# Altium schematic sheet Dosing_Main_v3.SchDoc(Dosing_Main_v3)
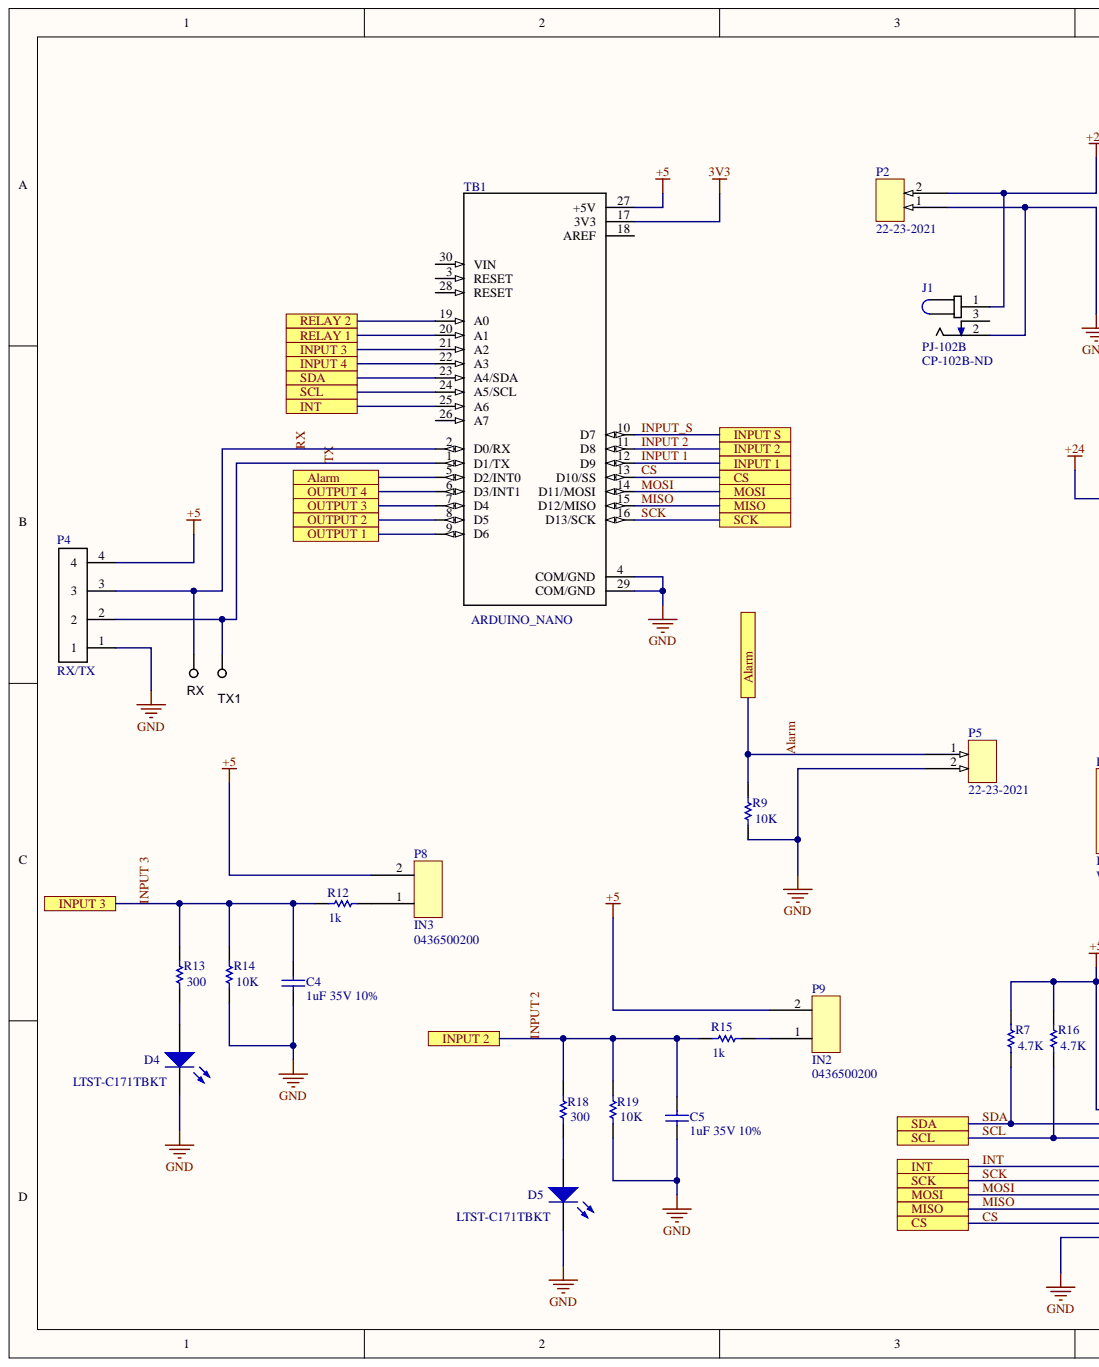
[diagram p0: Dosing_Main_v3.SchDoc, left]
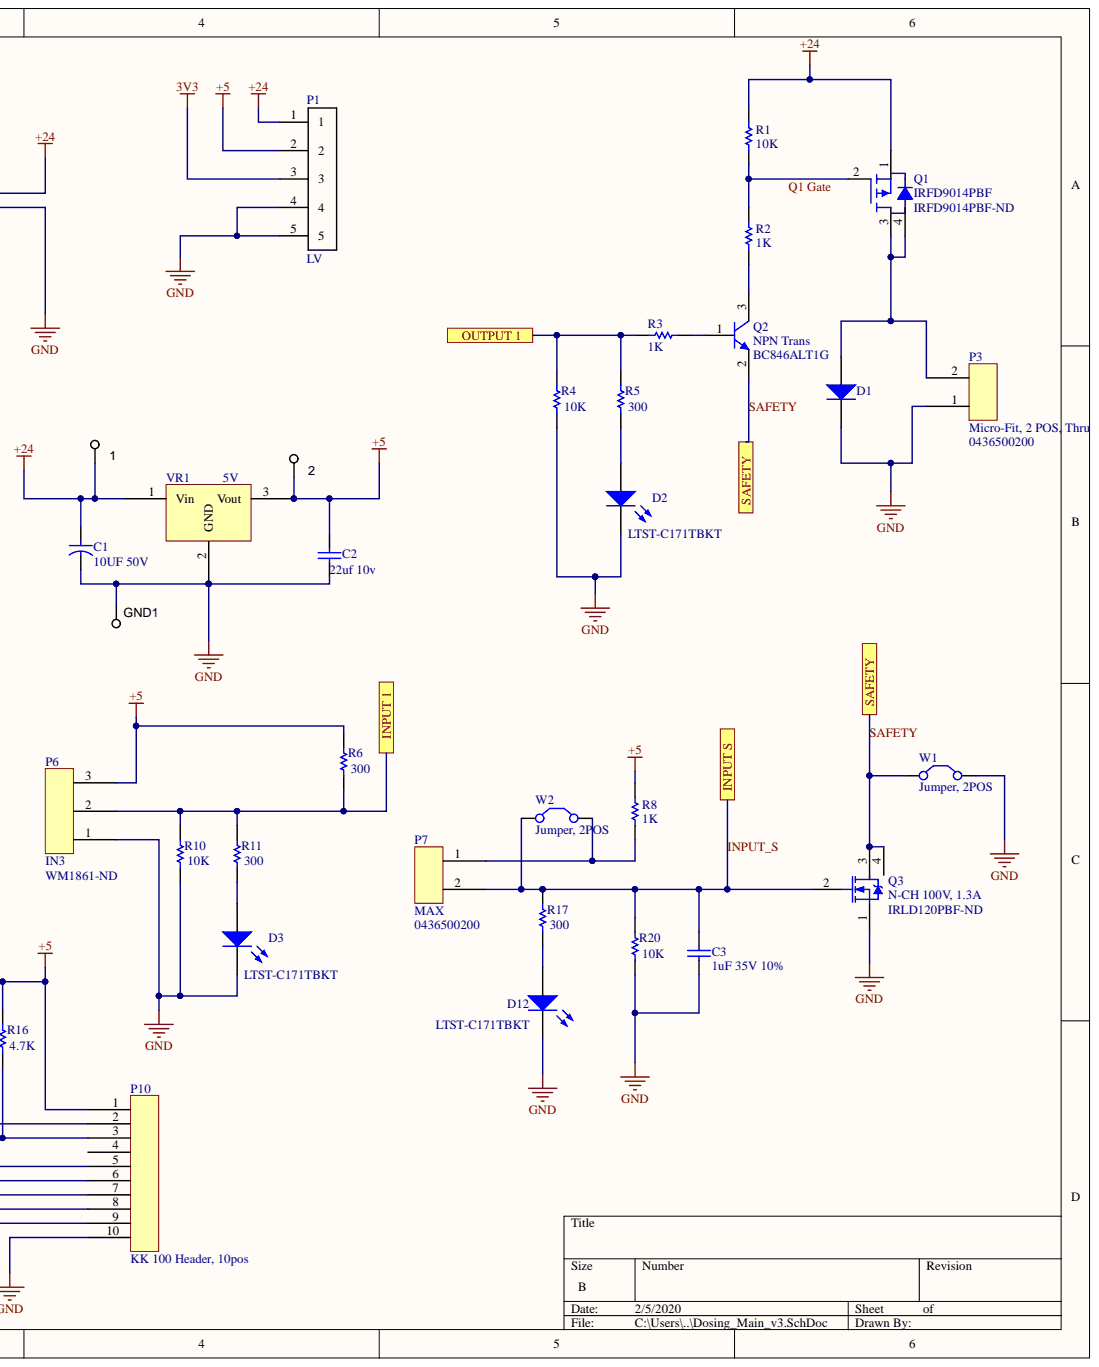
[diagram p1: Dosing_Main_v3.SchDoc, right]

## Parts
1  TESTPOINT_BIG_BLK1  [TPTHD_C_BLK1]  (value not drawn)  (no BOM)
2  TESTPOINT_BIG_BLK1  [TPTHD_C_BLK1]  (value not drawn)  (no BOM)
C1  10UF 50V  [RAD-5x11]
C2  22uf 10v  [RAD-4x7]
C3  1uF 35V 10%  Voltage Rating (DC): 35V  Capacitance: 1uF  [RAD 2x 0.2]
C4  1uF 35V 10%  Voltage Rating (DC): 35V  Capacitance: 1uF  [RAD 2x 0.2]
C5  1uF 35V 10%  Voltage Rating (DC): 35V  Capacitance: 1uF  [RAD 2x 0.2]
D1  Diode 1N4001  [DO-41]  (value not drawn)
D2  LTST-C171TBKT  [DIOC2011X08M]
D3  LTST-C171TBKT  [DIOC2011X08M]
D4  LTST-C171TBKT  [DIOC2011X08M]
D5  LTST-C171TBKT  [DIOC2011X08M]
D12  LTST-C171TBKT  [DIOC2011X08M]
GND1  TESTPOINT_BIG_BLK1  [TPTHD_C_BLK1]  (value not drawn)  (no BOM)
J1  PJ-102B  Supplier Part Number 1: CP-102B-ND  [CUI_PJ-102AH]
P1  LV  [OSTVN05A150]
P2  22-23-2021  [MOLEX-6373(2)]
P3  Micro-Fit, 2 POS, Thru  Manufacturer Part Number 1: 0436500200  [Molex 43650-0200]
P4  RX/TX  [OSTVN04A150]
P5  22-23-2021  [MOLEX-6373(2)]
P6  IN3  Supplier Part Number 1: WM1861-ND  [Molex 43650-0300]
P7  MAX  Manufacturer Part Number 1: 0436500200  [Molex 43650-0200]
P8  IN3  Manufacturer Part Number 1: 0436500200  [Molex 43650-0200]
P9  IN2  Manufacturer Part Number 1: 0436500200  [Molex 43650-0200]
P10  KK 100 Header, 10pos  [MOLEX-6373(10)]
Q1  IRFD9014PBF  Supplier Part Number 1: IRFD9014PBF-ND  [HVMDIP]
Q2  NPN Trans  Polarity: NPN  [SOT95P237X110-3M]
Q3  N-CH 100V, 1.3A  Supplier Part Number 2: IRLD120PBF-ND  [HVMDIP]
R1  10K  [RES_0805]
R2  1K  [RES_0805]
R3  1K  [RES_0805]
R4  10k  [RES_0805]
R5  300  [RES_0805]
R6  300  [RES_0805]
R7  4.7k  [RES_0805]
R8  1K  [RES_0805]
R9  10k  [RES_0805]
R10  10k  [RES_0805]
R11  300  [RES_0805]
R12  1k  [RES_0805]
R13  300  [RES_0805]
R14  10k  [RES_0805]
R15  1k  [RES_0805]
R16  4.7k  [RES_0805]
R17  300  [RES_0805]
R18  300  [RES_0805]
R19  10k  [RES_0805]
R20  10k  [RES_0805]
TB1  ARDUINO_NANO  [NANO proto]
TP1  RX  [TPTHD_C_BLK1]  (no BOM)
TX1  TX  [TPTHD_C_BLK1]  (no BOM)
VR1  5V  [SIP3 REG]
W1  Jumper, 2POS  [1X2 JUMPER]
W2  Jumper, 2POS  [1X2 JUMPER]

## Ports
Alarm, CS, INPUT 1, INPUT 2, INPUT 3, INPUT 4, INPUT S, INT, MISO, MOSI, OUTPUT 1, OUTPUT 2, OUTPUT 3, OUTPUT 4, RELAY 1, RELAY 2, SAFETY, SCK, SCL, SDA

## Nets
3V3: P1.3 TB1.17(3V3)
+5: 2.1 C2.1 P1.2 P4.4 P6.3 P8.2 P9.2 P10.1 R6.1 R7.1 R8.2 R16.1 TB1.27(+5V) VR1.3
+24: 1.1 C1.1 J1.1 P1.1 P2.2 Q1.1 R1.2 VR1.1
GND: C1.2 C2.2 C3.2 C4.2 C5.2 D1.2 D2.2 D3.2 D4.2 D5.2 D12.2 GND1.1 J1.2 P1.4 P1.5 P2.1 P3.1 P4.1 P5.2 P6.1 P10.10 Q3.1 R4.2 R9.2 R10.2 R14.2 R19.2 R20.2 TB1.4(COM/GND) TB1.29(COM/GND) VR1.2 W1.2
Alarm: P5.1 R9.1 TB1.5(D2/INT0) ; port Alarm
CS: P10.9 TB1.13(D10/SS) ; port CS
INPUT 1: P6.2 R6.2 R10.1 R11.1 TB1.12(D9) ; port INPUT 1
INPUT 2: C5.1 R15.1 R18.1 R19.1 TB1.11(D8) ; port INPUT 2
INPUT 3: C4.1 R12.1 R13.1 R14.1 TB1.21(A2) ; port INPUT 3
INPUT 4: TB1.22(A3) ; port INPUT 4
INPUT_S: C3.1 P7.2 Q3.2 R17.1 R20.1 TB1.10(D7) W2.1 ; port INPUT S
INT: P10.5 TB1.25(A6) ; port INT
MISO: P10.8 TB1.15(D12/MISO) ; port MISO
MOSI: P10.7 TB1.14(D11/MOSI) ; port MOSI
OUTPUT 1: R3.2 R4.1 R5.1 TB1.9(D6) ; port OUTPUT 1
OUTPUT 2: TB1.8(D5) ; port OUTPUT 2
OUTPUT 3: TB1.7(D4) ; port OUTPUT 3
OUTPUT 4: TB1.6(D3/INT1) ; port OUTPUT 4
RELAY 1: TB1.20(A1) ; port RELAY 1
RELAY 2: TB1.19(A0) ; port RELAY 2
RX: P4.3 TB1.2(D0/RX) TP1.1
SAFETY: Q2.2 Q3.3 Q3.4 W1.1 ; port SAFETY
SCK: P10.6 TB1.16(D13/SCK) ; port SCK
SCL: P10.3 R16.2 TB1.24(A5/SCL) ; port SCL
SDA: P10.2 R7.2 TB1.23(A4/SDA) ; port SDA
TX: P4.2 TB1.1(D1/TX) TX1.1
NetD1_1: D1.1 P3.2 Q1.3 Q1.4
NetD2_1: D2.1 R5.2
NetD3_1: D3.1 R11.2
NetD4_1: D4.1 R13.2
NetD5_1: D5.1 R18.2
NetD12_1: D12.1 R17.2
NetP7_1: P7.1 R8.1 W2.2
NetP8_1: P8.1 R12.2
NetP9_1: P9.1 R15.2
NetQ1_2: Q1.2 R1.1 R2.2
NetQ2_1: Q2.1 R3.1
NetQ2_3: Q2.3 R2.1
Q1 Gate:

Not connected: J1 3; P10 4; TB1 3(RESET) 18(AREF) 26(A7) 28(RESET) 30(VIN)

## Library data of the parts
1 2 GND1 TP1 TX1 = TESTPOINT_BIG_BLK1: TEST POINT; VALUE: VALUE; MANF_P#: MANF_P#; MANF: MANF
  1 2: Library Name: Passives_CE.SchLib
  GND1 TP1 TX1: Library Name: Connectors_CE.SchLib
C1 = UFW1H100MDD1TD: CAP ALUM 10UF 50V 20% RADIAL; Manufacturer: Nichicon; Ripple Current: 65mA; Life (Hours): 2000Hour; Dielectric Material: Aluminium; Voltage Rating (DC): 50V; Voltage Rating: 50V; Tolerance: 20%; Termination: Radial; Supplier Part Number 1: 493-11003-1-ND; Supplier 1: Digi-Key; Stock 1: 10402; Pricing 1: 1=0.23, 10=0.16, 100=0.0907, 500=0.06404, 1000=0.05603 (USD); Packaging: Tape and Reel; Package Quantity: 1; Mount: Through Hole; Min Operating Temperature: -40°C; Max Operating Temperature: 85°C; Manufacturer Part Number: UFW1H100MDD1TD; Library Name: Passives_CE.SchLib; Lead Pitch: 0.079inch; Height - Seated (Max): 0.492inch; Height: 11mm; Diameter: 5mm; ComponentLink1URL: http://nichicon-us.com/english/products/pdfs/e-ufw.pdf; ComponentLink1Description: http://nichicon-us.com/english/products/pdfs/e-ufw.pdf; Component Kind: Standard; Case/Package: Radial; Capacitance: 10uF
C2 = Cap: 22uf 10v Capacitor, electrolytic; Manufacturer Part Number: UPW1A220MDD6; Manufacturer: Nichicon; Tolerance: 20%; Ripple Current: 65mA; Polarity: Polar; Life (Hours): 2000Hour; Leakage Current: 6.6uA; Impedance: 2R; ESR (Equivalent Series Resistance): 5R; Dielectric Material: Aluminium; Voltage Rating (DC): 10V; Voltage Rating: 10V; Value: 22uF; Termination: Radial; Supplier Part Number 1: 493-1728-ND; Supplier 1: Digi-Key; Stock 1: 7153; Simulation: CAP; Signal Integrity: Cap; Radiation Hardening: No; Published: 8-Jun-2000; Pricing 1: 1=0.25, 10=0.17, 100=0.0966, 500=0.06816, 1000=0.05964, 2500=0.0568, 5000=0.05254 (USD); Packaging: Bulk; PackageReference: RAD-0.3; Package Quantity: 200; PackageDescription: Radial Cap, Thru-Hole; 2 Leads; 0.3 in Pin Spacing; Number of Pins: 2; Mount: Through Hole; Min Operating Temperature: -55°C; Max Operating Temperature: 105°C; Library Name: Passives_CE.SchLib; Length: 7mm; Lead Pitch: 0.059inch; Lead Free: Lead Free; LatestRevisionNote: Re-released for DXP Platform.; LatestRevisionDate: 17-Jul-2002; Height - Seated (Max): 0.276inch; Height: 7mm; Diameter: 4mm; ComponentLink1URL: http://nichicon-us.com/english/products/pdfs/e-upw.pdf; ComponentLink1Description: http://nichicon-us.com/english/products/pdfs/e-upw.pdf; Component Kind: Standard; Case/Package: Radial; Capacitance: 22uF; Publisher: Altium Limited
C3-C5 = TAP105K035CRW: Cap Tant Solid 1uF 35V 10% (4.5 X 8.5mm) Radial 5mm 8 Ohm 125C T/R; Manufacturer 1: Kyocera AVX; Tolerance: 20%; Polarity: Polar; Life (Hours): 1000Hour; Leakage Current: 500nA; Lead Diameter: 0.5mm; ESR (Equivalent Series Resistance): 8R; Dissipation Factor: 4%; Voltage Rating: 35V; Voltage: 35V; Termination: Radial; Supplier Part Number 1: 478-7365-1-ND; Supplier 1: Digi-Key; Stock 1: 3150; RoHS: Yes; Radiation Hardening: No; Pricing 1: 1=0.75000, 10=0.52900, 100=0.34840, 500=0.25806 (USD); Packaging: Tape and Reel; Package Quantity: 1; Number of Pins: 2; Mount: Through Hole; Min Operating Temperature: -55°C; Max Operating Temperature: 125°C; Manufacturer Part Number 1: TAP105K035CRW; Library Name: Capacitors_CE.SchLib; Length: 8.5mm; Lead Pitch: 5mm; Lead Free: Lead Free; Height - Seated (Max): 0.335inch; Height: 10mm; Features: General Purpose; Depth: 4.5mm; ComponentLink5URL: https://datasheet.ciiva.com/6229/tap-6229118.pdf?src-supplier=Digi-Key; ComponentLink5Description: https://datasheet.ciiva.com/6229/tap-6229118.pdf?src-supplier=Digi-Key; ComponentLink4URL: https://datasheet.ciiva.com/6229/tap-6229117.pdf?src-supplier=Digi-Key; ComponentLink4Description: https://datasheet.ciiva.com/6229/tap-6229117.pdf?src-supplier=Digi-Key; ComponentLink3URL: https://datasheet.ciiva.com/16218/tap-16218508.pdf?src-supplier=Future+Electronics; ComponentLink3Description: https://datasheet.ciiva.com/16218/tap-16218508.pdf?src-supplier=Future+Electronics; ComponentLink2URL: https://datasheet.ciiva.com/12882/tap-12882407.pdf?src-supplier=Mouser; ComponentLink2Description: https://datasheet.ciiva.com/12882/tap-12882407.pdf?src-supplier=Mouser; ComponentLink1URL: https://datasheet.ciiva.com/3001/tap-3001681.pdf?src-supplier=Avnet; ComponentLink1Description: https://datasheet.ciiva.com/3001/tap-3001681.pdf?src-supplier=Avnet; Component Kind: Standard; Case/Package: Dipped
D1 = Diode 1N4001: 1 Amp General Purpose Rectifier; Manufacturer: ON Semiconductor / Fairchild; Voltage Rating (DC): 50V; Power Dissipation: 3W; Polarity: Standard; Peak Reverse Current: 5uA; Peak Non-Repetitive Surge Current: 30A; Output Current: 1A; Forward Voltage: 1.1V; Element Configuration: Single; Capacitance: 15pF; Width: 0.25inch; Weight: 0.245g; Supplier Part Number 1: 1N4001FSCT-ND; Supplier 1: Digi-Key; Stock 1: 0; Simulation: 1N4001; Signal Integrity: 1N4001; Reverse Voltage: 50V; REACH SVHC: No SVHC; Radiation Hardening: No; Published: 8-Jun-2000; Pricing 1: 1=0.17 (USD); Packaging: Tape and Reel; PackageVersion: Aug-1999; PackageReference: DO-41; Package Quantity: 1000; PackageDescription: Diode, Axial; Body 5.2 x 2.7 mm (LxDia. max), Lead Dia. 0.86 mm (max); Number of Pins: 2; Mount: Through Hole; Min Operating Temperature: -55°C; Max Surge Current: 30A; Max Reverse Voltage (DC): 50V; Max Repetitive Reverse Voltage (Vrrm): 50V; Max Operating Temperature: 175°C; Max Forward Surge Current (Ifsm): 30A; Manufacturer Part Number: 1N4001; Library Name: Passives_custom.SchLib; Length: 5.2mm; Lead Free: Lead Free; LatestRevisionNote: Re-released for DXP Platform.; LatestRevisionDate: 17-Jul-2002; Height: 5.2mm; Forward Current: 1A; Diameter: 2.72mm; DatasheetVersion: 1998; Current Rating: 1A; Contact Plating: Matte,Tin; ComponentLink9URL: http://www.farnell.com/datasheets/1923868.pdf?src-supplier=Element14; ComponentLink9Description: http://www.farnell.com/datasheets/1923868.pdf?src-supplier=Element14; ComponentLink8URL: https://www.verical.com/server-webapp/html/getDatasheet?partID=148723&src-supplier=Verical; ComponentLink8Description: https://www.verical.com/server-webapp/html/getDatasheet?partID=148723&src-supplier=Verical; ComponentLink7URL: http://www.farnell.com/datasheets/1504060.pdf?src-supplier=Element14; ComponentLink7Description: http://www.farnell.com/datasheets/1504060.pdf?src-supplier=Element14; ComponentLink6URL: http://uk.rs-online.com/webdocs/137d/0900766b8137d734.pdf?src-supplier=RSComponents; ComponentLink6Description: http://uk.rs-online.com/webdocs/137d/0900766b8137d734.pdf?src-supplier=RSComponents; ComponentLink5URL: http://www.mouser.com/ds/2/149/1N4002-81693.pdf?src-supplier=Mouser; ComponentLink5Description: http://www.mouser.com/ds/2/149/1N4002-81693.pdf?src-supplier=Mouser; ComponentLink4URL: https://www.fairchildsemi.com/datasheets/1N/1N4007.pdf?src-supplier=Avnet; ComponentLink4Description: https://www.fairchildsemi.com/datasheets/1N/1N4007.pdf?src-supplier=Avnet; ComponentLink3URL: http://www.onsemi.com/pub/Collateral/1N4001-D.PDF?src-supplier=Digi-Key; ComponentLink3Description: http://www.onsemi.com/pub/Collateral/1N4001-D.PDF?src-supplier=Digi-Key; ComponentLink2URL: http://www.fairchildsemi.com/ds/1N%2F1N4001.pdf; ComponentLink2Description: Datasheet; ComponentLink1URL: http://www.fairchildsemi.com/; ComponentLink1Description: Manufacturer Link; Component Kind: Standard; Code_JEDEC: DO-204-AL; Average Rectified Current: 1A; Publisher: Altium Limited
D2-D5 D12 = LTST-C171TBKT: LED Uni-Color Blue 468nm 2-Pin Chip LED T/R; Manufacturer: Vishay Lite-On; Viewing Angle: 130°; Test Current: 20mA; Reverse Voltage: 5V; Power Dissipation: 76mW; Number of LEDs: 1; Luminous Intensity: 180mcd; Lens Transparency: Clear; Lens Style: Clear,Colorless,Diffused,Rectangular; Lens Color: Clear,Colorless; Forward Voltage: 3.8V; Dominant Wavelength: 475nm; Width: 1.25mm; Wavelength: 468nm; Supplier 1: Digi-Key; Stock 1: 426824; Radiation Hardening: No; Pricing 1: 1=0.5, 10=0.36, 25=0.2764, 100=0.1802, 250=0.13212, 500=0.11212, 1000=0.09209 (USD); Packaging: Tape and Reel; Package Quantity: 1; Number of Pins: 2; Number of Elements: 1; Mount: Surface Mount; Min Operating Temperature: -20°C; Max Operating Temperature: 80°C; Manufacturer Part Number: LTST-C171TBKT; Library Name: Passives_CE.SchLib; Length: 2mm; Lead Free: Lead Free; Illumination Color: Blue; Height: 0.8mm; Forward Current: 20mA; Depth: 1.25mm; ComponentLink5URL: http://www1.futureelectronics.com/doc/LITE%20ON/LITE-ON%20LTST-C171TBKT.pdf; ComponentLink5Description: http://www1.futureelectronics.com/doc/LITE%20ON/LITE-ON%20LTST-C171TBKT.pdf; ComponentLink4URL: https://www.verical.com/server-webapp/html/getDatasheet?partID=225754; ComponentLink4Description: https://www.verical.com/server-webapp/html/getDatasheet?partID=225754; ComponentLink3URL: http://www.mouser.com/ds/2/239/Lite-On-LTST-C171TBKT-187246.pdf; ComponentLink3Description: http://www.mouser.com/ds/2/239/Lite-On-LTST-C171TBKT-187246.pdf; ComponentLink2URL: http://uk.rs-online.com/webdocs/0dc0/0900766b80dc029b.pdf; ComponentLink2Description: http://uk.rs-online.com/webdocs/0dc0/0900766b80dc029b.pdf; ComponentLink1URL: http://optoelectronics.liteon.com/upload/download/DS22-2000-233/LTST-C171TBKT(0630).pdf; ComponentLink1Description: http://optoelectronics.liteon.com/upload/download/DS22-2000-233/LTST-C171TBKT(0630).pdf; Component Kind: Standard; Color: Blue; Case Code (Metric): 2012; Case Code (Imperial): 0805; Case/Package: 0805
J1 = PJ-102B: CONN PWR JACK 2.5X5.5MM SOLDER; Manufacturer 1: CUI; Voltage Rating: 24V; Shielding: Unshielded; Number of Conductors: 2; Insulation Resistance: 100MR; Gender: Male; Current Rating: 2.5A; Termination: Through Hole; Supplier 1: Digi-Key; Stock 1: 82713; RoHS: Yes; Pricing 1: 1=0.64000, 10=0.60000, 25=0.54000, 50=0.48000, 100=0.46000, 250=0.42000, 500=0.40000, 1000=0.33000, 2500=0.30000 (USD); Packaging: Bulk; Package Quantity: 280; Orientation: Horizontal; Mount: Through Hole; Min Operating Temperature: -25°C; Max Operating Temperature: 85°C; Manufacturer Part Number 1: PJ-102B; Library Name: Connectors_CE.SchLib; Lead Free: Lead Free; Housing Material: Thermoplastic; Housing Color: Black; Flammability Rating: UL94 V-0; Diameter: 2.5mm; Contact Plating: Nickel; Contact Material: Copper; Contact Gender: Male; Connector Type: Jack; ComponentLink3URL: http://www.cui.com/Product/Resource/FuturePDF/PJ-102B.pdf?src-supplier=Future+Electronics; ComponentLink3Description: http://www.cui.com/Product/Resource/FuturePDF/PJ-102B.pdf?src-supplier=Future+Electronics; ComponentLink2URL: https://www.cui.com/product/resource/digikeypdf/pj-102b.pdf?src-supplier=Digi-Key; ComponentLink2Description: https://www.cui.com/product/resource/digikeypdf/pj-102b.pdf?src-supplier=Digi-Key; ComponentLink1URL: http://www.mouser.com/ds/2/670/PJ-102B-524146.pdf?src-supplier=Mouser; ComponentLink1Description: http://www.mouser.com/ds/2/670/PJ-102B-524146.pdf?src-supplier=Mouser; Component Kind: Standard; Color: Black
P1 = OSTVN05A150: CONN TERM BLOCK 2.54MM 5POS PCB; Manufacturer: On-Shore Technology; Wire Gauge (Min): 20AWG; Wire Gauge (Max): 30AWG; Voltage: 125V; Plating: Brass,Steel; Pitch: 0.1inch; Number of Positions Per Level: 5; Number of Levels: 1; Current: 6A; Termination: Screw; Supplier 1: Digi-Key; Stock 1: 2057; Pricing 1: 1=1.73, 10=1.212, 25=1.1304, 50=1.026, 100=1.0215, 250=0.8626, 500=0.8172, 1000=0.7264, 5000=0.5902 (USD); Packaging: Bulk; Number of Pins: 5; Mount: Through Hole; Min Operating Temperature: -30°C; Max Operating Temperature: 105°C; Manufacturer Part Number: OSTVN05A150; Library Name: Connectors_custom.SchLib; Housing Material: Thermoplastic; Contact Plating: Brass; ComponentLink1URL: http://www.on-shore.com/wp-content/uploads/2015/09/ostvnxxa150.pdf; ComponentLink1Description: http://www.on-shore.com/wp-content/uploads/2015/09/ostvnxxa150.pdf; Component Kind: Standard; Color: Green
P2 P5 = 22-23-2021: KK 100, 2 Position 2.54mm Solder Stra; Manufacturer 1: Molex; Voltage Rating (DC): 250V; Number of Positions: 2; Max Current Rating: 4A; Insulation Resistance: 500GR; Glow Wire Compliant: Non-compliant; Gender: Male; Contact Resistance: 10mR; Approvals: UL,CSA; Voltage Rating: 250V; Termination: Through Hole; Supplier Part Number 1: 900-0022232021-ND; Supplier 1: Digi-Key; Stock 1: 79931; RoHS: Yes; REACH SVHC: Unknown; Radiation Hardening: No; Pricing 1: 1=0.17000, 10=0.16100, 100=0.11150, 500=0.09438, 1000=0.07722, 5000=0.06864, 10000=0.06221 (USD); Pitch: 2.54mm; Packaging: Bulk; Package Quantity: 3000; Orientation: Straight; Number of Rows: 1; Number of Contacts: 2; Number of Circuits: 2; Mount: Through Hole; Min Operating Temperature: 0°C; Max Operating Temperature: 75°C; Manufacturer Part Number 1: 22-23-2021; Library Name: Connectors_CE.SchLib; Length: 5.08mm; Lead Free: Lead Free; Housing Material: Nylon; Housing Color: White; Height: 10.67mm; Halogen Free: Low Halogen; Flammability Rating: UL94 V-0; Depth: 6.35mm; Current Rating: 4A; Contact Plating: Tin,Copper; Contact Material: Brass; ComponentLink6URL: https://datasheet.ciiva.com/18453/70190606-18453638.pdf?src-supplier=Allied+Electronics; ComponentLink6Description: https://datasheet.ciiva.com/18453/70190606-18453638.pdf?src-supplier=Allied+Electronics; Component Kind: Standard; Color: White
P3 P7-P9 = Micro-Fit, 2 POS, Thru: CONN HEADER R/A 2POS 3MM; Manufacturer 1: Molex; Number of Positions: 2; Number of Ports: 1; Contact Resistance: 10mR; Connector Type: Male Pin; Termination: Solder; Supplier Part Number 1: WM1860-ND; Supplier 1: Digi-Key; Stock 1: 19425; RoHS: Yes; Pricing 1: 1=0.96000, 10=0.84100, 100=0.72560, 500=0.59364, 1000=0.52768, 5000=0.46172, 10000=0.43863 (USD); Pitch: 3mm; Packaging: Tray; Orientation: Right Angle; Number of Terminals: 2; Number of Rows: 1; Number of Contacts: 2; Mount: Through Hole; Min Operating Temperature: -40°C; Max Operating Temperature: 105°C; Library Name: Connectors_CE.SchLib; Length: 9.65mm; Housing Material: Polymer; Housing Color: Black; Flammability Rating: UL94 V-0; Fastening Type: Ramp; Depth: 9.9mm; Contact Plating: Tin; Contact Material: Brass; ComponentLink1URL: https://www.molex.com/pdm_docs/sd/436500200_sd.pdf; ComponentLink1Description: Molex; Component Kind: Standard; Color: Black
P4 = OSTVN04A150: CONN TERM BLOCK 2.54MM 4POS PCB; Manufacturer: On-Shore Technology; Wire Gauge (Min): 20AWG; Wire Gauge (Max): 30AWG; Voltage: 125V; Plating: Brass,Steel; Pitch: 0.1inch; Number of Positions Per Level: 4; Number of Levels: 1; Current: 6A; Termination: Screw; Supplier 1: Digi-Key; Stock 1: 10834; Pricing 1: 1=1.38, 10=0.969, 25=0.904, 50=0.8204, 100=0.8168, 250=0.68972, 500=0.6534, 1000=0.5808, 5000=0.4719 (USD); Packaging: Bulk; Number of Pins: 4; Mount: Through Hole; Min Operating Temperature: -30°C; Max Operating Temperature: 105°C; Manufacturer Part Number: OSTVN04A150; Library Name: Connectors_CE.SchLib; Housing Material: Thermoplastic; Contact Plating: Brass; ComponentLink1URL: http://www.on-shore.com/wp-content/uploads/2015/09/ostvnxxa150.pdf; ComponentLink1Description: http://www.on-shore.com/wp-content/uploads/2015/09/ostvnxxa150.pdf; Component Kind: Standard; Color: Green
P6 = Micro-Fit, 3 POS: CONN HEADER R/A 3POS 3MM; Manufacturer Part Number 1: 43650-0300; Manufacturer 1: Molex; Working Voltage: 250V; Voltage Rating: 600V; Number of Positions: 3; Max Current Rating: 5A; Insulation Resistance: 1GR; Glow Wire Compliant: Compliant; Gender: Male; Contact Resistance: 10mR; Connector Type: Power,Rectangular; Voltage Rating (DC): 250V; Voltage Rating (AC): 250V; Termination: Solder; Supplier 1: Digi-Key; Stock 1: 40125; RoHS: Yes; REACH SVHC: Unknown; Radiation Hardening: No; Pricing 1: 1=1.10000, 10=0.96400, 100=0.83160, 500=0.68040, 1000=0.60480, 5000=0.52920, 10000=0.50274 (USD); Pitch: 3mm; Packaging: Tray; Package Quantity: 2975; Orientation: Right Angle; Number of Rows: 1; Number of Poles: 3; Number of Contacts: 3; Number of Circuits: 3; Mount: Through Hole,PCB; Min Operating Temperature: -40°C; Max Voltage Rating (AC): 250V; Max Operating Temperature: 105°C; Library Name: Connectors_CE.SchLib; Length: 12.65mm; Lead Free: Lead Free; Housing Material: Thermoplastic; Housing Color: Black; Height: 14.4mm; Halogen Free: Low Halogen; Flammability Rating: UL94 V-0; Depth: 9.9mm; Current Rating: 5A; Contact Plating: Tin,Lead,Bright; Contact Material: Brass,Tin; ComponentLink1URL: https://www.molex.com/pdm_docs/sd/436500300_sd.pdf; ComponentLink1Description: Molex; Component Kind: Standard; Color: Black
Q1 = IRFD9014PBF: Trans MOSFET P-CH 60V 1.1A 4-Pin HVMDIP; Manufacturer 1: Vishay; Turn-On Delay Time: 11ns; Turn-Off Delay Time: 10ns; Threshold Voltage: -4V; Row Spacing: 7.62mm; Rise Time: 63ns; Rds On Max: 500mR; Power Dissipation: 1.3W; Number of Elements: 1; Input Capacitance: 270pF; Gate to Source Voltage (Vgs): 20V; Element Configuration: Single; Dual Supply Voltage: -60V; Width: 0.197inch; Supplier 1: Digi-Key; Stock 1: 1966; RoHS: Yes; REACH SVHC: No SVHC; Radiation Hardening: No; Pricing 1: 1=0.83000, 10=0.74400, 100=0.52960, 500=0.44892, 1000=0.36569, 2500=0.36065, 5000=0.32786 (USD); Packaging: Rail/Tube; Package Quantity: 2500; On-State Resistance: 500mR; Number of Pins: 4; Nominal Vgs: -4V; Mount: Through Hole; Min Operating Temperature: -55°C; Max Power Dissipation: 1.3W; Max Operating Temperature: 175°C; Manufacturer Part Number 1: IRFD9014PBF; Library Name: Passives_CE.SchLib; Length: 0.247inch; Lead Pitch: 2.54mm; Lead Free: Lead Free; Height: 0.133inch; Fall Time: 63ns; Drain to Source Voltage (Vdss): 60V; Drain to Source Resistance: 500mR; Drain to Source Breakdown Voltage: 60V; Continuous Drain Current (ID): 1.1A; ComponentLink1URL: http://www.vishay.com/docs/91136/sihfd901.pdf; ComponentLink1Description: Vishay Web; Component Kind: Standard; Case/Package: DIP
Q2 = BC846ALT1G: TRANS NPN 65V 0.1A SOT23; Manufacturer 1: ON Semiconductor; Transition Frequency: 100MHz; Power Dissipation: 300mW; Number of Elements: 1; Max Collector Current: 100mA; hFE Min: 110; Emitter Base Voltage (VEBO): 6V; Element Configuration: Single; Collector Emitter Saturation Voltage: 600mV; Collector Base Voltage (VCBO): 80V; Width: 1.3mm; Voltage Rating (DC): 65V; Supplier Part Number 1: BC846ALT1GOSCT-ND; Supplier 1: Digi-Key; Stock 1: 322425; RoHS: Yes; REACH SVHC: No SVHC; Radiation Hardening: No; Pricing 1: 1=0.12000, 10=0.11200, 100=0.04700, 500=0.03694, 1000=0.02565 (USD); Packaging: Tape and Reel; Package Quantity: 1; Number of Pins: 3; Min Operating Temperature: -55°C; Max Power Dissipation: 300mW; Max Operating Temperature: 150°C; Max Frequency: 100MHz; Max Breakdown Voltage: 65V; Manufacturer Part Number 1: BC846ALT1G; Library Name: Passives_CE.SchLib; Length: 2.9mm; Lead Free: Lead Free; Height: 0.94mm; Halogen Free: Halogen Free; Gain Bandwidth Product: 100MHz; Frequency: 100MHz; Current Rating: 100mA; Contact Plating: Tin,Matte; ComponentLink1URL: https://www.mouser.com/datasheet/2/308/BC846ALT1-D-1293035.pdf; ComponentLink1Description: Mouser; Component Kind: Standard; Collector Emitter Voltage (VCEO): 65V; Collector Emitter Breakdown Voltage: 65V; Case/Package: SOT-23-3
Q3 = IRLD120PBF: N-CH MOSFET, HVMDIP, 100V 1.3A; Manufacturer: Vishay; Turn-On Delay Time: 9.8ns; Turn-Off Delay Time: 21ns; Threshold Voltage: 2V; Rise Time: 64ns; Rds On Max: 270mR; Power Dissipation: 1.3W; Number of Elements: 1; Input Capacitance: 490pF; Gate to Source Voltage (Vgs): 10V; Element Configuration: Single; Width: 0.197inch; Voltage Rating (DC): 100V; Supplier 2: Digi-Key; Supplier 1: Digi-Key; Stock 2: 18660; Stock 1: 9747; REACH SVHC: Unknown; Radiation Hardening: No; Pricing 2: 1=0.93, 10=0.817, 100=0.6302, 500=0.46684, 1000=0.37347 (USD); Pricing 1: 1=0.93, 10=0.817, 100=0.6302, 500=0.46684, 1000=0.37347 (USD); Packaging: Rail/Tube; Package Quantity: 2500; On-State Resistance: 270mR; Number of Pins: 4; Mount: Through Hole; Min Operating Temperature: -55°C; Max Power Dissipation: 1.3W; Max Operating Temperature: 175°C; Manufacturer Part Number: IRLD120PBF; Library Name: Passives_CE.SchLib; Length: 0.247inch; Lead Free: Lead Free; Height: 0.133inch; Fall Time: 64ns; Drain to Source Voltage (Vdss): 100V; Drain to Source Resistance: 270mR; Drain to Source Breakdown Voltage: 100V; Current Rating: 1.3A; Continuous Drain Current (ID): 1.3A; ComponentLink10URL: http://www.farnell.com/datasheets/140677.pdf?src-supplier=Element14; ComponentLink10Description: http://www.farnell.com/datasheets/140677.pdf?src-supplier=Element14; ComponentLink9URL: http://www.vishay.com/docs/91310/sihld120.pdf?src-supplier=Avnet+Europe; ComponentLink9Description: http://www.vishay.com/docs/91310/sihld120.pdf?src-supplier=Avnet+Europe; ComponentLink8URL: http://www.vishay.com/docs/91310/sihld120.pdf?src-supplier=Digi-Key; ComponentLink8Description: http://www.vishay.com/docs/91310/sihld120.pdf?src-supplier=Digi-Key; ComponentLink7URL: http://www.vishay.com/docs/91310/sihld120.pdf?src-supplier=Future+Electronics; ComponentLink7Description: http://www.vishay.com/docs/91310/sihld120.pdf?src-supplier=Future+Electronics; ComponentLink6URL: https://www.verical.com/server-webapp/html/getDatasheet?partID=84732&src-supplier=Verical; ComponentLink6Description: https://www.verical.com/server-webapp/html/getDatasheet?partID=84732&src-supplier=Verical; ComponentLink5URL: http://www.mouser.com/ds/2/427/sihld120-86406.pdf?src-supplier=Mouser; ComponentLink5Description: http://www.mouser.com/ds/2/427/sihld120-86406.pdf?src-supplier=Mouser; ComponentLink4URL: http://www.farnell.com/datasheets/140677.pdf; ComponentLink4Description: http://www.farnell.com/datasheets/140677.pdf; ComponentLink3URL: http://www.vishay.com/docs/91310/sihld120.pdf; ComponentLink3Description: http://www.vishay.com/docs/91310/sihld120.pdf; ComponentLink2URL: https://www.verical.com/server-webapp/html/getDatasheet?partID=84732; ComponentLink2Description: https://www.verical.com/server-webapp/html/getDatasheet?partID=84732; ComponentLink1URL: http://www.mouser.com/ds/2/427/sihld120-86406.pdf; ComponentLink1Description: http://www.mouser.com/ds/2/427/sihld120-86406.pdf; Component Kind: Standard; Case/Package: DIP
R1-R3 R8 = 10K Resistor 0805: RES 1% 1/8W 0805; Simulation: RESISTOR; Signal Integrity: Res; PackageReference: 0805; Library Name: Passives_custom.SchLib; Component Kind: Standard
  R1: Value: 10K
  R2 R3 R8: Value: 1K
R4-R7 R9-R20 = RESISTOR, 0805: RES 1% 1/8W 0805; Simulation: RESISTOR; Signal Integrity: Res; PackageReference: 0805; Library Name: Passives_CE.SchLib; Component Kind: Standard
  R4 R9 R10 R14 R19 R20: Value: 10K
  R5 R6 R11 R13 R17 R18: Value: 300
  R7 R16: Value: 4.7K
  R12 R15: Value: 1k
TB1 = ARDUINO_NANO: Dev.kit: Arduino; UART; ICSP, USB B mini, pin strips; MP: ARDUINO NANO; SnapEDA_Link: https://www.snapeda.com/parts/ARDUINO%20NANO/Arduino/view-part/?ref=snap; Price: None; Package: None; MF: Arduino; Library Name: Modules_CE.SchLib; Component Kind: Standard; Availability: Unavailable
VR1 = VX7805-500: 5V regulator; Manufacturer: CUI; Number of Outputs: 1; Supplier Part Number 1: 102-4244-ND; Supplier 1: Digi-Key; Stock 1: 2470; Pricing 1: 1=2.78, 5=2.742, 20=2.703, 40=2.6235, 60=2.544, 100=2.4645, 260=2.385, 500=2.226 (USD); Manufacturer Part Number: VX7805-500; Library Name: Modules_CE.SchLib; ComponentLink6URL: http://datasheet.octopart.com/VX7805-500-CUI-datasheet-86656418.pdf; ComponentLink6Description: http://datasheet.octopart.com/VX7805-500-CUI-datasheet-86656418.pdf; ComponentLink5URL: http://datasheet.octopart.com/VX7805-500-CUI-datasheet-103080323.pdf; ComponentLink5Description: http://datasheet.octopart.com/VX7805-500-CUI-datasheet-103080323.pdf; ComponentLink4URL: http://datasheet.octopart.com/VX7805-500-CUI-datasheet-102996270.pdf; ComponentLink4Description: http://datasheet.octopart.com/VX7805-500-CUI-datasheet-102996270.pdf; ComponentLink3URL: http://datasheet.octopart.com/VX7805-500-CUI-datasheet-83193723.pdf; ComponentLink3Description: http://datasheet.octopart.com/VX7805-500-CUI-datasheet-83193723.pdf; ComponentLink2URL: http://datasheet.octopart.com/VX7805-500-CUI-datasheet-103115547.pdf; ComponentLink2Description: http://datasheet.octopart.com/VX7805-500-CUI-datasheet-103115547.pdf; ComponentLink1URL: http://datasheet.octopart.com/VX7805-500-CUI-datasheet-103787283.pdf; ComponentLink1Description: http://datasheet.octopart.com/VX7805-500-CUI-datasheet-103787283.pdf; Component Kind: Standard; Case/Package: SIP
W1 W2 = Jumper, 2POS: 0.1" JUMPER; Published: 24-Mar-1999; PackageReference: RAD-0.2; PackageDescription: Radial Cap, Thru-Hole; 2 Leads; 0.2 in Pin Spacing; Library Name: Connectors_CE.SchLib; LatestRevisionNote: Re-released for DXP Platform.; LatestRevisionDate: 17-Jul-2002; Component Kind: Standard; Publisher: Altium Limited
P10 = KK 100 Header, 10pos: Library Name: Connectors_custom.SchLib; Component Kind: Standard
